# Altium schematic sheet Wuerfel.SchDoc(Wuerfel)
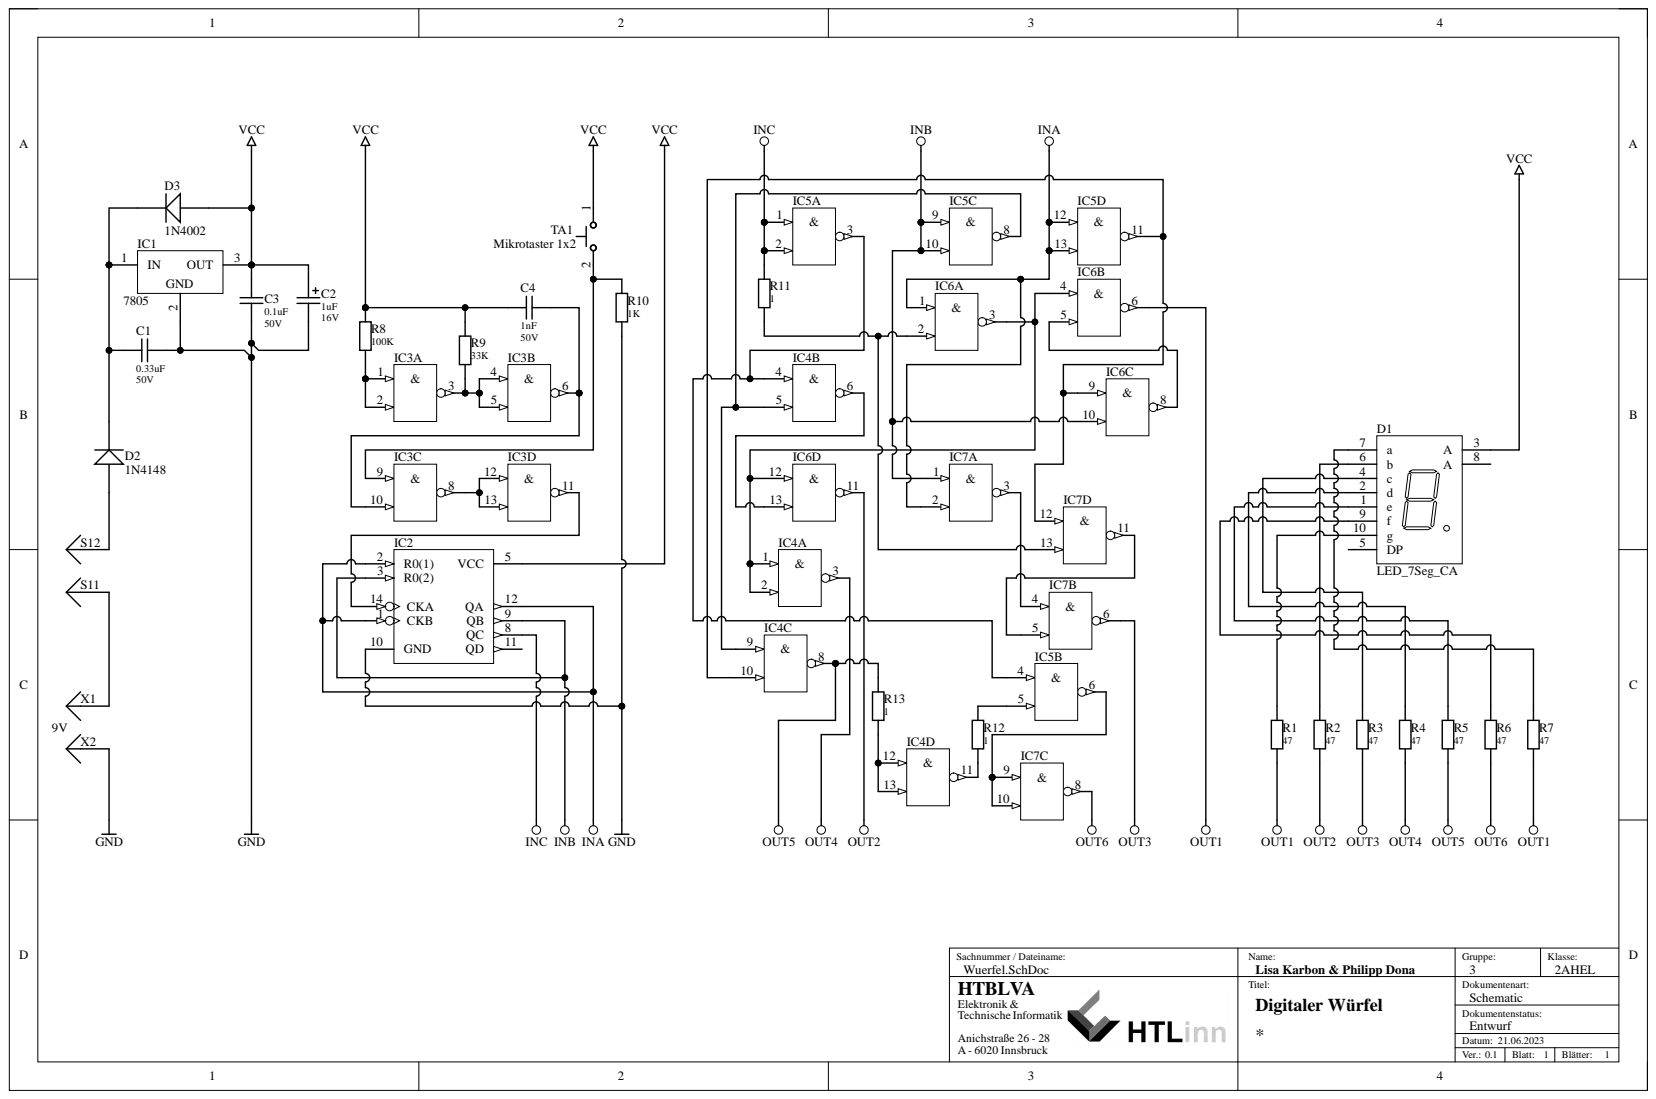
[diagram p0: Wuerfel.SchDoc]

## Parts
C1  0.33uF  Rated Voltage: 50V  [C_RM2-025X075]
C2  1uF  Rated Voltage: 16V  [C_POL_RM2-8,5]
C3  0.1uF  Rated Voltage: 50V  [C_RM2-025X075]
C4  1nF  Rated Voltage: 50V  [C_RM2-025X075]
D1  LED_7Seg_CA  [LED_7Seg]
D2  1N4148  [D_DO35-RM3]
D3  1N4002  [D_DO41-RM4]
IC1  7805  [TO-220]
IC2  SN74LS93  [DIP14]  (value not drawn)
IC3  SN74LS00  [DIP14]  (value not drawn)  (parts A,B,C,D)
IC4  SN74LS00  [DIP14]  (value not drawn)  (parts A,B,C,D)
IC5  SN74LS00  [DIP14]  (value not drawn)  (parts A,B,C,D)
IC6  SN74LS00  [DIP14]  (value not drawn)  (parts A,B,C,D)
IC7  SN74LS00  [DIP14]  (value not drawn)  (parts A,B,C,D)
R1  47  [R_0207/RM4]
R2  47  [R_0207/RM4]
R3  47  [R_0207/RM4]
R4  47  [R_0207/RM4]
R5  47  [R_0207/RM4]
R6  47  [R_0207/RM4]
R7  47  [R_0207/RM4]
R8  value 100K  [R_0207/RM4]  (hidden comment: 68K)
R9  33K  [R_0207/RM4]
R10  1K  [R_0207/RM4]
R11  1  [R_0207/RM4]
R12  1  [R_0207/RM4]
R13  1  [R_0207/RM4]
S11  Plug  [PIN1]  (value not drawn)
S12  X2  [PIN1]  (value not drawn)
TA1  Mikrotaster 1x2  [SW_DTSM-61NT/R - 1x2]
X1  Plug  [PIN1]  (value not drawn)
X2  Plug  [PIN1]  (value not drawn)

## Nets
GND: C1.1 C2.2 C3.2 IC1.2 IC2.10(GND) IC3.7 IC4.7 IC5.7 IC6.7 IC7.7 R10.1 X2.1
INA: IC2.1(CKB) IC2.2(R0(1)) IC2.12(QA) IC5.12(&) IC5.13 IC6.1(&) IC7.2
INB: IC2.3(R0(2)) IC2.9(QB) IC5.9(&) IC5.10 IC6.10 IC7.1(&)
INC: IC2.8(QC) IC5.1(&) IC5.2 R11.2
OUT1: IC6.6 R1.1 R7.1
OUT2: IC6.11 R2.1
OUT3: IC7.6 R3.1
OUT4: IC4.3 R4.1
OUT5: IC4.8 R5.1 R13.2
OUT6: IC7.8 R6.1
VCC: C2.1 C3.1 C4.1 D1.3(A) D3.A IC1.3 IC2.5(VCC) IC3.14 IC4.14 IC5.14 IC6.14 IC7.14 R8.1 R9.1 TA1.1
NetC1_2: C1.2 D2.C D3.C IC1.1
NetC4_2: C4.2 IC3.6 IC3.10
NetD1_1: D1.1(e) R5.2
NetD1_2: D1.2(d) R4.2
NetD1_4: D1.4(c) R3.2
NetD1_6: D1.6(b) R2.2
NetD1_7: D1.7(a) R7.2
NetD1_9: D1.9(f) R6.2
NetD1_10: D1.10(g) R1.2
NetD2_A: D2.A S12.1
NetIC2_14: IC2.14(CKA) IC3.11
NetIC3_1: IC3.1(&) IC3.2 R8.2
NetIC3_3: IC3.3 IC3.4(&) IC3.5 R9.2
NetIC3_8: IC3.8 IC3.12(&) IC3.13
NetIC3_9: IC3.9(&) R10.2 TA1.2
NetIC4_1: IC4.1(&) IC4.2 IC6.3 IC6.4(&) IC6.12(&)
NetIC4_4: IC4.4(&) IC5.3 IC5.4(&)
NetIC4_5: IC4.5 IC4.9(&) IC5.8
NetIC4_6: IC4.6 IC6.13
NetIC4_10: IC4.10 IC5.11 IC6.9(&) IC7.12(&)
NetIC4_11: IC4.11 R12.2
NetIC4_12: IC4.12(&) IC4.13 R13.1
NetIC5_5: IC5.5 R12.1
NetIC5_6: IC5.6 IC7.9(&) IC7.10
NetIC6_2: IC6.2 IC7.13 R11.1
NetIC6_5: IC6.5 IC6.8
NetIC7_3: IC7.3 IC7.4(&)
NetIC7_5: IC7.5 IC7.11
NetS11_1: S11.1 X1.1

Not connected: D1 5(DP) 8(A); IC2 11(QD)

## Library data of the parts
C1 C3 C4 = C: Capacitor; Usage: only permitted for non-commercial purposes at HTL I Innsbruck; Component Kind: Standard; LatestRevisionDate: 07-Sep-2019; Library Name: HTLInn_RLCQ.IntLib; Published: 21-Feb-2011; Signal Integrity: C; Simulation: C
  C1: Value: 0.33uF
  C3: Value: 0.1uF
  C4: Value: 1nF
C2 = C_POL: Polarized Capacitor; Usage: only permitted for non-commercial purposes at HTL I Innsbruck; Component Kind: Standard; LatestRevisionDate: 07-Sep-2019; Library Name: HTLInn_RLCQ.IntLib; Published: 21-Feb-2011; Signal Integrity: C_POL; Simulation: C_POL; Value: 1uF
D1 = LED_7Seg_CA: Red 7-Segment Display, CA, 13 mm Slim Font; SimulationModellSource: currently not available; Usage: only permitted for non-commercial purposes at HTL I Innsbruck; Component Kind: Standard; LatestRevisionDate: 21-Sep-2017; Published: 21-Sep-2017; Simulation: Model Name; Library Name: HTLinn_Opto.IntLib
D2 = 1N4148: Si-Diode; 75V, 0.300A, 4.00ns (Diodes Inc.); SimulationModellSource: Diodes Incorporated; Usage: only permitted for non-commercial purposes at HTL I Innsbruck; Component Kind: Standard; LatestRevisionDate: 01-Nov-2012; Published: 21-Feb-2011; Signal Integrity: 1N4148; Simulation: 1N4148W; Library Name: HTLinn_D.IntLib
D3 = 1N4002: Si-Diode; 100V, 1.00A, 3.00us (Diodes, Inc.); SimulationModellSource: Diodes Incorporated; Usage: only permitted for non-commercial purposes at HTL I Innsbruck; Component Kind: Standard; LatestRevisionDate: 01-Nov-2012; Published: 21-Feb-2011; Signal Integrity: 1N4002; Simulation: DI_1N4002; Library Name: HTLinn_D.IntLib
IC1 = 78xx: Positive-Voltage Regulator; SimulationModellSource: <no Sim-Modell found so far>; Usage: only permitted for non-commercial purposes at HTL I Innsbruck; Component Kind: Standard; LatestRevisionDate: 31-Oct-2012; Published: 21-Feb-2011; Simulation: Model Name; Library Name: HTLinn_PowerSupply.IntLib
IC2 = SN74LS93: 4-Bit Binary Counter; SimulationModellSource: TI Logic Counter; Usage: only permitted for non-commercial purposes at HTL I Innsbruck; Component Kind: Standard; LatestRevisionDate: 31-Oct-2012; Published: 21-Feb-2011; Signal Integrity: SN74LS93; Simulation: SN74LS93; Library Name: HTLinn_Logic_TTL.IntLib
IC3-IC7 = SN74LS00: Quad 2-Input Positive-NAND Gate; SimulationModellSource: TI Logic Gate 2; Usage: only permitted for non-commercial purposes at HTL I Innsbruck; Component Kind: Standard; LatestRevisionDate: 31-Oct-2012; Published: 21-Feb-2011; Signal Integrity: SN74LS00; Simulation: SN74LS00; Library Name: HTLinn_Logic_TTL.IntLib; Publisher: Michael Kupfner
R1-R13 = R: Resistor; Usage: only permitted for non-commercial purposes at HTL I Innsbruck; Component Kind: Standard; LatestRevisionDate: 07-Sep-2019; Library Name: HTLInn_RLCQ.IntLib; Published: 21-Feb-2011; Signal Integrity: R; Simulation: R
  R1-R7: Value: 47
  R9: Value: 33K
  R10: Value: 1K
  R11-R13: Value: 1
S12 = Plug: Component Kind: Standard; Description: Plug; LatestRevisionDate: 17-Jul-2002; LatestRevisionNote: Re-released for DXP Platform.; Library Name: Miscellaneous Connectors.IntLib; Signal Integrity: Connector; Simulation: Model Name; Publisher: Altium Limited
TA1 = Mikrotaster 1x2: Mikrotaster 1x2; max DC 50V; SimulationModellSource: <No SimModell found so far>; Usage: only permitted for non-commercial purposes at HTL I Innsbruck; Component Kind: Standard; LatestRevisionDate: 07-Sep-2019; Published: 29-Mar-2017; Simulation: Model Name; Library Name: HTLinn_Miscellaneous.IntLib; Publisher: Michael Kupfner
S11 X1 X2 = Plug: Component Kind: Standard; Description: Plug; LatestRevisionDate: 17-Jul-2002; LatestRevisionNote: Re-released for DXP Platform.; Library Name: Miscellaneous Connectors.IntLib; Signal Integrity: Connector; Simulation: Model Name; Publisher: Altium Limited
